# Altium schematic sheet SIM7600x.SchDoc
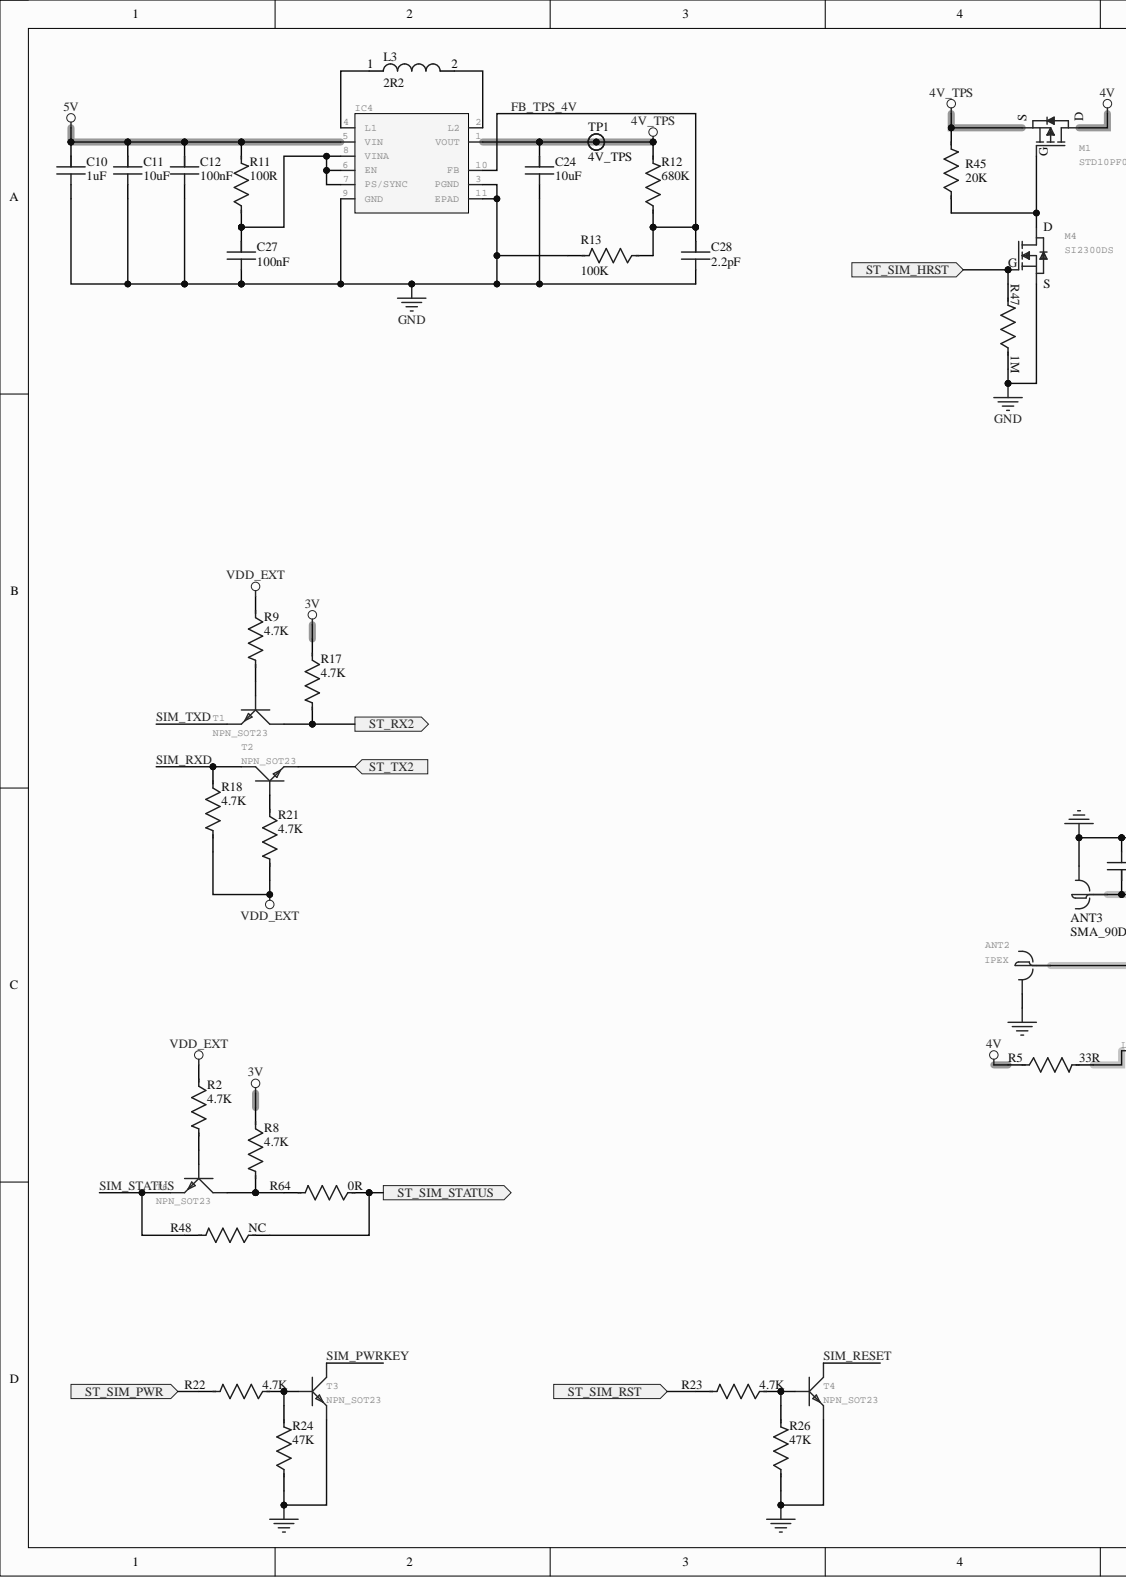
[diagram p0: SIM7600x.SchDoc, left]
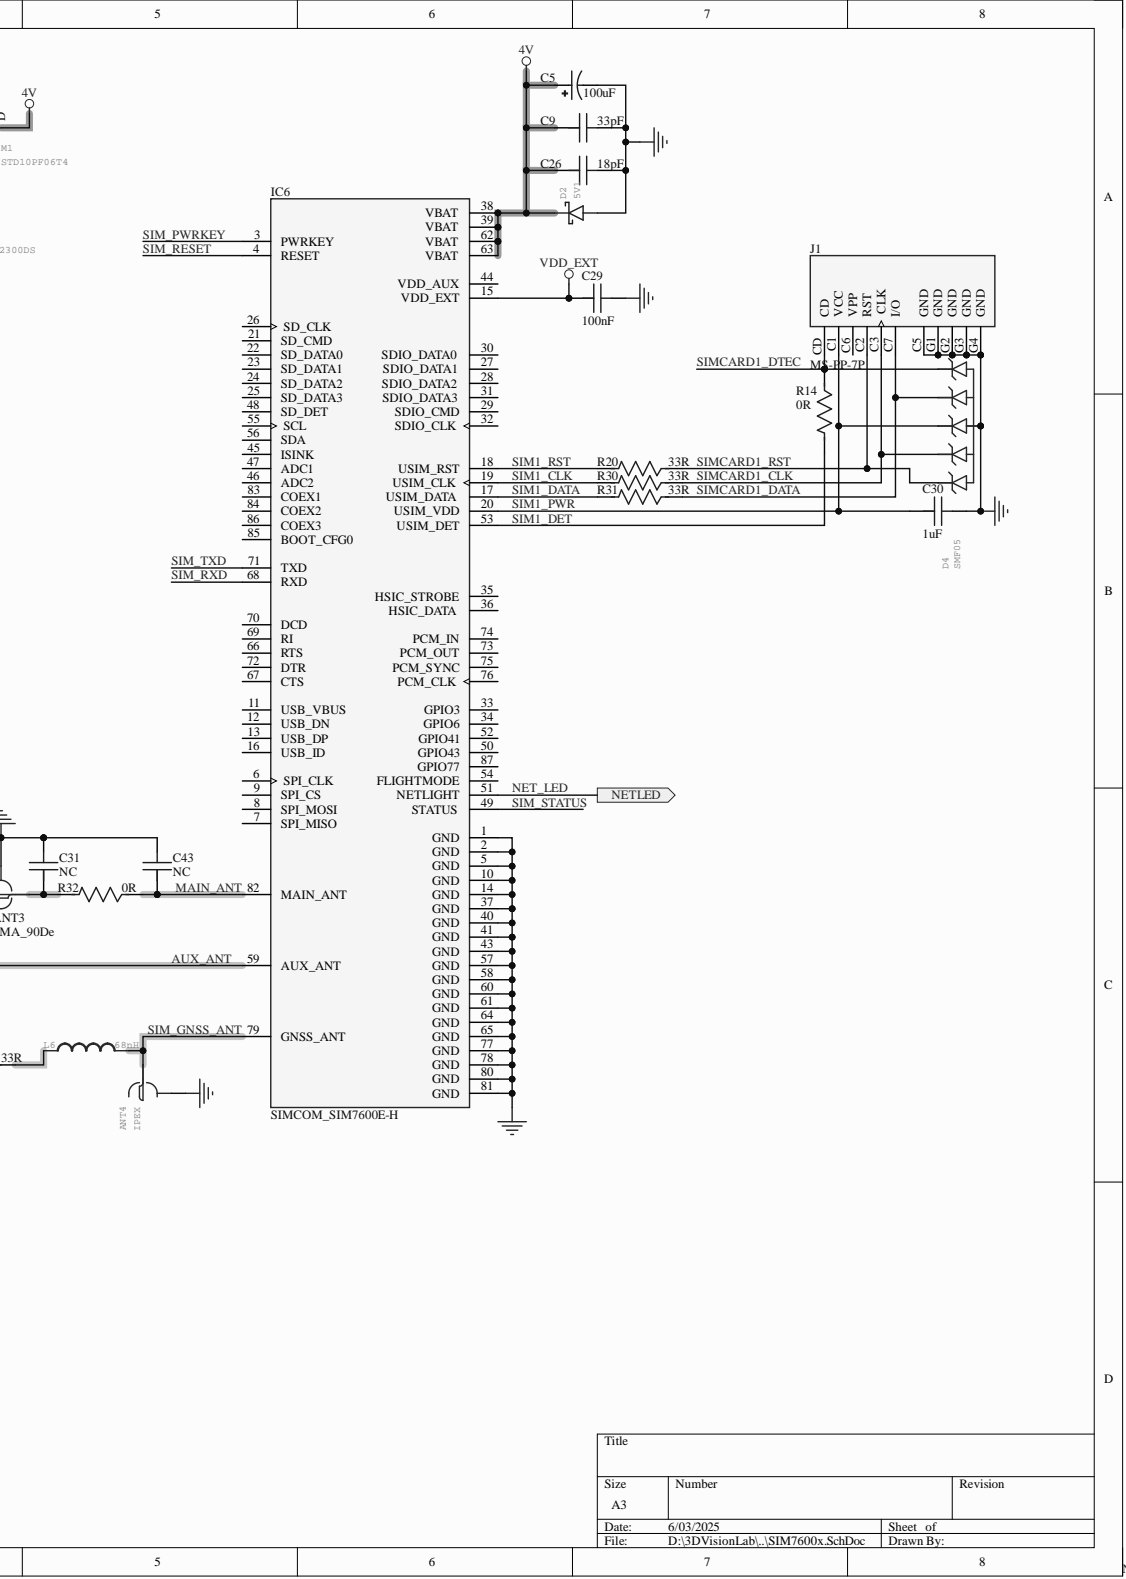
[diagram p1: SIM7600x.SchDoc, right]

## Parts
ANT2  IPEX  [IPEX]
ANT3  SMA_90De  [SMA_90De]
ANT4  IPEX  [IPEX]
C5  100uF  [1411P]
C9  33pF  [C0603]
C10  1uF  [C0603]
C11  10uF  [C0603]
C12  100nF  [C0603]
C24  10uF  [C0603]
C26  18pF  [C0603]
C27  100nF  [C0603]
C28  2.2pF  [C0603]
C29  100nF  [C0603]
C30  1uF  [C0603]
C31  NC  [C0603]
C43  NC  [C0603]
D2  5V1  [SOD123]
D4  SMF05  [SOT363]
IC4  TPS63XXX  [VSON-10]  (value not drawn)
IC6  SIMCOM_SIM7600E-H  [SIMCOM_SIM7600E-H]
J1  MS-PP-7P  [GCT_SIM8065-6-1-14-01-A_REVA1]
L3  2R2  [L0630]
L6  68nH  [C0603]
M1  STD10PF06T4  [TO252-3]
M4  SI2300DS  [SOT23]
R2  4.7K  [R0603]
R5  33R  [R0603]
R8  4.7K  [R0603]
R9  4.7K  [R0603]
R11  100R  [R0603]
R12  680K  [R0603]
R13  100K  [R0603]
R14  0R  [R0603]
R17  4.7K  [R0603]
R18  4.7K  [R0603]
R20  33R  [R0603]
R21  4.7K  [R0603]
R22  4.7K  [R0603]
R23  4.7K  [R0603]
R24  47K  [R0603]
R26  47K  [R0603]
R30  33R  [R0603]
R31  33R  [R0603]
R32  0R  [R0603]
R45  20K  [R0603]
R47  1M  [R0603]
R48  NC  [R0603]
R64  0R  [R0603]
T1  NPN_SOT23  [SOT23]
T2  NPN_SOT23  [SOT23]
T3  NPN_SOT23  [SOT23]
T4  NPN_SOT23  [SOT23]
T6  NPN_SOT23  [SOT23]
TP1  4V_TPS  [TestPoint_1MM]

## Ports
NETLED, ST_RX2, ST_SIM_HRST, ST_SIM_PWR, ST_SIM_RST, ST_SIM_STATUS, ST_TX2

## Nets
3V: R8.1 R17.1
4V: C5.1 C9.1 C26.1 D2.C IC6.38(VBAT) IC6.39(VBAT) IC6.62(VBAT) IC6.63(VBAT) M1.3 R5.1
4V_TPS: C24.1 IC4.1(VOUT) M1.2 R12.2 R45.2 TP1.1
5V: C10.1 C11.1 C12.1 IC4.5(VIN) R11.2
GND: ANT2.2,3 ANT3.2, 3, 4, 5 ANT4.2,3 C5.2 C9.2 C10.2 C11.2 C12.2 C24.2 C26.2 C27.2 C28.2 C29.2 C30.2 C31.2 C43.2 D2.A D4.2 IC4.3(PGND) IC4.9(GND) IC4.11(EPAD) IC6.1(GND) IC6.2(GND) IC6.5(GND) IC6.10(GND) IC6.14(GND) IC6.37(GND) IC6.40(GND) IC6.41(GND) IC6.43(GND) IC6.57(GND) IC6.58(GND) IC6.60(GND) IC6.61(GND) IC6.64(GND) IC6.65(GND) IC6.77(GND) IC6.78(GND) IC6.80(GND) IC6.81(GND) J1.C5(GND) J1.G1(GND) J1.G2(GND) J1.G3(GND) J1.G4(GND) M4.2 R13.2 R24.1 R26.1 R47.1 T3.2 T4.2
VDD_EXT: C29.1 IC6.15(VDD_EXT) R2.1 R9.1 R18.2 R21.2
AUX_ANT: ANT2.1 IC6.59(AUX_ANT)
FB_TPS_4V: C28.1 IC4.10(FB) R12.1 R13.1
MAIN_ANT: C43.1 IC6.82(MAIN_ANT) R32.1
NET_LED: IC6.51(NETLIGHT) ; port NETLED
SIM1_CLK: IC6.19(USIM_CLK) R30.2
SIM1_DATA: IC6.17(USIM_DATA) R31.2
SIM1_DET: IC6.53(USIM_DET) R14.2
SIM1_PWR: C30.1 D4.4 IC6.20(USIM_VDD) J1.C1(VCC)
SIM1_RST: IC6.18(USIM_RST) R20.2
SIM_GNSS_ANT: ANT4.1 IC6.79(GNSS_ANT) L6.2
SIM_PWRKEY: IC6.3(PWRKEY) T3.3
SIM_RESET: IC6.4(RESET) T4.3
SIM_RXD: IC6.68(RXD) R18.1 T2.3
SIM_STATUS: IC6.49(STATUS) R48.2 T6.2
SIM_TXD: IC6.71(TXD) T1.2
SIMCARD1_CLK: D4.3 J1.C3(CLK) R30.1
SIMCARD1_DATA: D4.5 J1.C7(I/O) R31.1
SIMCARD1_DTEC: D4.6 J1.CD R14.1
SIMCARD1_RST: D4.1 J1.C2(RST) R20.1
ST_RX2: R17.2 T1.3 ; port ST_RX2
ST_SIM_HRST: M4.1 R47.2 ; port ST_SIM_HRST
ST_SIM_PWR: R22.2 ; port ST_SIM_PWR
ST_SIM_RST: R23.2 ; port ST_SIM_RST
ST_SIM_STATUS: R48.1 R64.1 ; port ST_SIM_STATUS
ST_TX2: T2.2 ; port ST_TX2
NetANT3_1: ANT3.1 C31.1 R32.2
NetC27_1: C27.1 IC4.6(EN) IC4.7(PS/SYNC) IC4.8(VINA) R11.1
NetIC4_2: IC4.2(L2) L3.2
NetIC4_4: IC4.4(L1) L3.1
NetIC6_6: IC6.6(SPI_CLK)
NetIC6_7: IC6.7(SPI_MISO)
NetIC6_8: IC6.8(SPI_MOSI)
NetIC6_9: IC6.9(SPI_CS)
NetIC6_11: IC6.11(USB_VBUS)
NetIC6_12: IC6.12(USB_DN)
NetIC6_13: IC6.13(USB_DP)
NetIC6_16: IC6.16(USB_ID)
NetIC6_21: IC6.21(SD_CMD)
NetIC6_22: IC6.22(SD_DATA0)
NetIC6_23: IC6.23(SD_DATA1)
NetIC6_24: IC6.24(SD_DATA2)
NetIC6_25: IC6.25(SD_DATA3)
NetIC6_26: IC6.26(SD_CLK)
NetIC6_27: IC6.27(SDIO_DATA1)
NetIC6_28: IC6.28(SDIO_DATA2)
NetIC6_29: IC6.29(SDIO_CMD)
NetIC6_30: IC6.30(SDIO_DATA0)
NetIC6_31: IC6.31(SDIO_DATA3)
NetIC6_32: IC6.32(SDIO_CLK)
NetIC6_33: IC6.33(GPIO3)
NetIC6_34: IC6.34(GPIO6)
NetIC6_35: IC6.35(HSIC_STROBE)
NetIC6_36: IC6.36(HSIC_DATA)
NetIC6_44: IC6.44(VDD_AUX)
NetIC6_45: IC6.45(ISINK)
NetIC6_46: IC6.46(ADC2)
NetIC6_47: IC6.47(ADC1)
NetIC6_48: IC6.48(SD_DET)
NetIC6_50: IC6.50(GPIO43)
NetIC6_52: IC6.52(GPIO41)
NetIC6_54: IC6.54(FLIGHTMODE)
NetIC6_55: IC6.55(SCL)
NetIC6_56: IC6.56(SDA)
NetIC6_66: IC6.66(RTS)
NetIC6_67: IC6.67(CTS)
NetIC6_69: IC6.69(RI)
NetIC6_70: IC6.70(DCD)
NetIC6_72: IC6.72(DTR)
NetIC6_73: IC6.73(PCM_OUT)
NetIC6_74: IC6.74(PCM_IN)
NetIC6_75: IC6.75(PCM_SYNC)
NetIC6_76: IC6.76(PCM_CLK)
NetIC6_83: IC6.83(COEX1)
NetIC6_84: IC6.84(COEX2)
NetIC6_85: IC6.85(BOOT_CFG0)
NetIC6_86: IC6.86(COEX3)
NetIC6_87: IC6.87(GPIO77)
NetJ1_C6: J1.C6(VPP)
NetL6_1: L6.1 R5.2
NetM1_1: M1.1 M4.3 R45.1
NetR2_2: R2.2 T6.1
NetR8_2: R8.2 R64.2 T6.3
NetR9_2: R9.2 T1.1
NetR21_1: R21.1 T2.1
NetR22_1: R22.1 R24.2 T3.1
NetR23_1: R23.1 R26.2 T4.1
NetLabel1:

## Library data of the parts
ANT2 ANT4 = IPEX: GateName_1: G$1; SymbolName_1: ANTENNA; Component Kind: Standard; DeviceSetName: IPEX; Package: IPEX; Library Name: UY_Schlib.SchLib; LibraryName: Dkoi
C5 = CAP_TAN_1411: Aluminum Electrolytic Capacitors - Radial Leaded 50VDC 1200uF 10000H 16x25mm; MF: Panasonic; MP: EEU-FS1H122; Availability: In Stock; Check_prices: https://www.snapeda.com/parts/EEU-FS1H122/Panasonic/view-part/?ref=eda; Component Kind: Standard; Package: RADIAL-2 Panasonic; Price: None; Purchase-URL: https://pricing.snapeda.com/search/part/EEU-FS1H122/?ref=eda; SnapEDA_Link: https://www.snapeda.com/parts/EEU-FS1H122/Panasonic/view-part/?ref=snap; Library Name: UY_Schlib.SchLib
C9-C12 C24 C26-C31 C43 = Capacitor: Component Kind: Standard; Library Name: UY_Schlib.SchLib
D2 = Zenner_SOD123: DeviceSetName: SS54F; GateName_1: G$1; SymbolName_1: DIODE_S; Component Kind: Standard; LibraryName: Uy_SMD; Package: SMAF; Library Name: UY_Schlib.SchLib
D4 = SMF05: GateName_1: G$1; Component Kind: Standard; DeviceSetName: SMF05; LibraryName: Uy_SMD; Package: SC7-6; SymbolName_1: SMF05; Library Name: UY_Schlib.SchLib
IC4 = TPS63XXX: High-Efficient Single Inductor Buck-Boost Converter With 1.8A Switches; GateName_1: G$1; SymbolName_1: TPS6300X; Component Kind: Standard; DeviceSetName: TPS63XXX; LibraryName: Uy_SMD; Package: VSON-10; Library Name: UY_Schlib.SchLib
IC6 = SIMCOM_SIM7600E-H: Multi-Band LTE-TDD/LTE-FDD/HSPA+ and GSM/GPRS/EDGE module; Availability: Unavailable; Component Kind: Standard; MP: SIM7600E-H; Package: None; Price: None; Library Name: UY_Schlib.SchLib
J1 = MS-PP-7P: Nano SIM Card Connector Push-Push Type, SMT, 6pin, 1.37mm Profile; MP: SIM8065-6-1-14-01-A_REVA1; Availability: Unavailable; Component Kind: Standard; Package: None; Price: None; Library Name: UY_Schlib.SchLib
L3 = Inductor 0630: HIGH POWER INDUCTOR 1040; MF: Wurth Electronics; MP: 74437368047; Availability: Unavailable; Component Kind: Standard; Package: Nonstandard Wurth Electronics; Price: None; SnapEDA_Link: https://www.snapeda.com/parts/74437368047/Wurth%20Electronics%20Inc./view-part/286863/?ref=snap; Library Name: UY_Schlib.SchLib
L6 = Inductor 0603: DeviceSetName: L0603; GateName_1: G$1; SymbolName_1: L; Component Kind: Standard; LibraryName: Uy_SMD; Package: 0603; Library Name: UY_Schlib.SchLib
M1 = MOSFETP_TO252: SOT-23; DeviceSetName: MOSFETN; GateName_1: G$1; SymbolName_1: MOSFET_N; Component Kind: Standard; LibraryName: Uy_SMD; Package: SOT23(1); Library Name: UY_Schlib.SchLib
M4 = MOSFETN_SOT23: SOT-23; GateName_1: G$1; SymbolName_1: MOSFET_N; Component Kind: Standard; DeviceSetName: MOSFETN; LibraryName: Uy_SMD; Package: SOT23(1); Library Name: UY_Schlib.SchLib
R2 R5 R8 R9 R11-R14 R17 R18 R20-R24 R26 R30-R32 R45 R47 R48 R64 = Resistor: SMD Resistor 0603; Manufacturer: Yageo; Manufacturer Part Number: RC0603FR-071KL; Max Power Dissipation: 100mW; Resistance Tolerance: 1%; Temperature Coefficient: 100ppm/°C; Value: 1kR; Voltage Rating: 50V; Case Code (Imperial): 0603; Case Code (Metric): 1608; Case/Package: 0603; Category: Chip SMD Resistors; Component Kind: Standard; Construction: Rectangular; Contact Plating: Tin,Matte; Depth: 0.8mm; Features: Moisture Resistant; Height: 0.45mm; Lead Free: 5697LeadFree; Length: 1.6mm; Max Operating Temperature: 155°C; Military Standard: Not; Min Operating Temperature: -55°C; Number of Terminations: 2; Packaging: Tape and Reel; Power Rating: 100mW; Pricing: 1=0.1, 10=0.018, 50=0.0106, 100=0.0088, 500=0.00592, 1000=0.00505 (USD); Reach SVHC Compliant: No; Resistor Type: Thick Film; RoHS: Yes; RoHS Compliant: Yes; Series: RC; Stock: 18662657; Supplier: Digi-Key; Supplier Part Number: 311-1.00KHRDKR-ND; Technology: THICK FILM; Termination: SMD/SMT; Tolerance: 1%; Library Name: UY_Schlib.SchLib
T1-T4 T6 = NPN_SOT23: BJT SOT23; DeviceSetName: NPN; GateName_1: G$1; Component Kind: Standard; LibraryName: Uy_SMD; Package: SOT23(1); SymbolName_1: NPN; Library Name: UY_Schlib.SchLib
TP1 = TestPoint_1MM: Component Kind: Standard; Library Name: UY_Schlib.SchLib
ANT3 = SMA_90De: Component Kind: Standard; Library Name: UY_Schlib.SchLib
